# Altium schematic sheet main.SchDoc
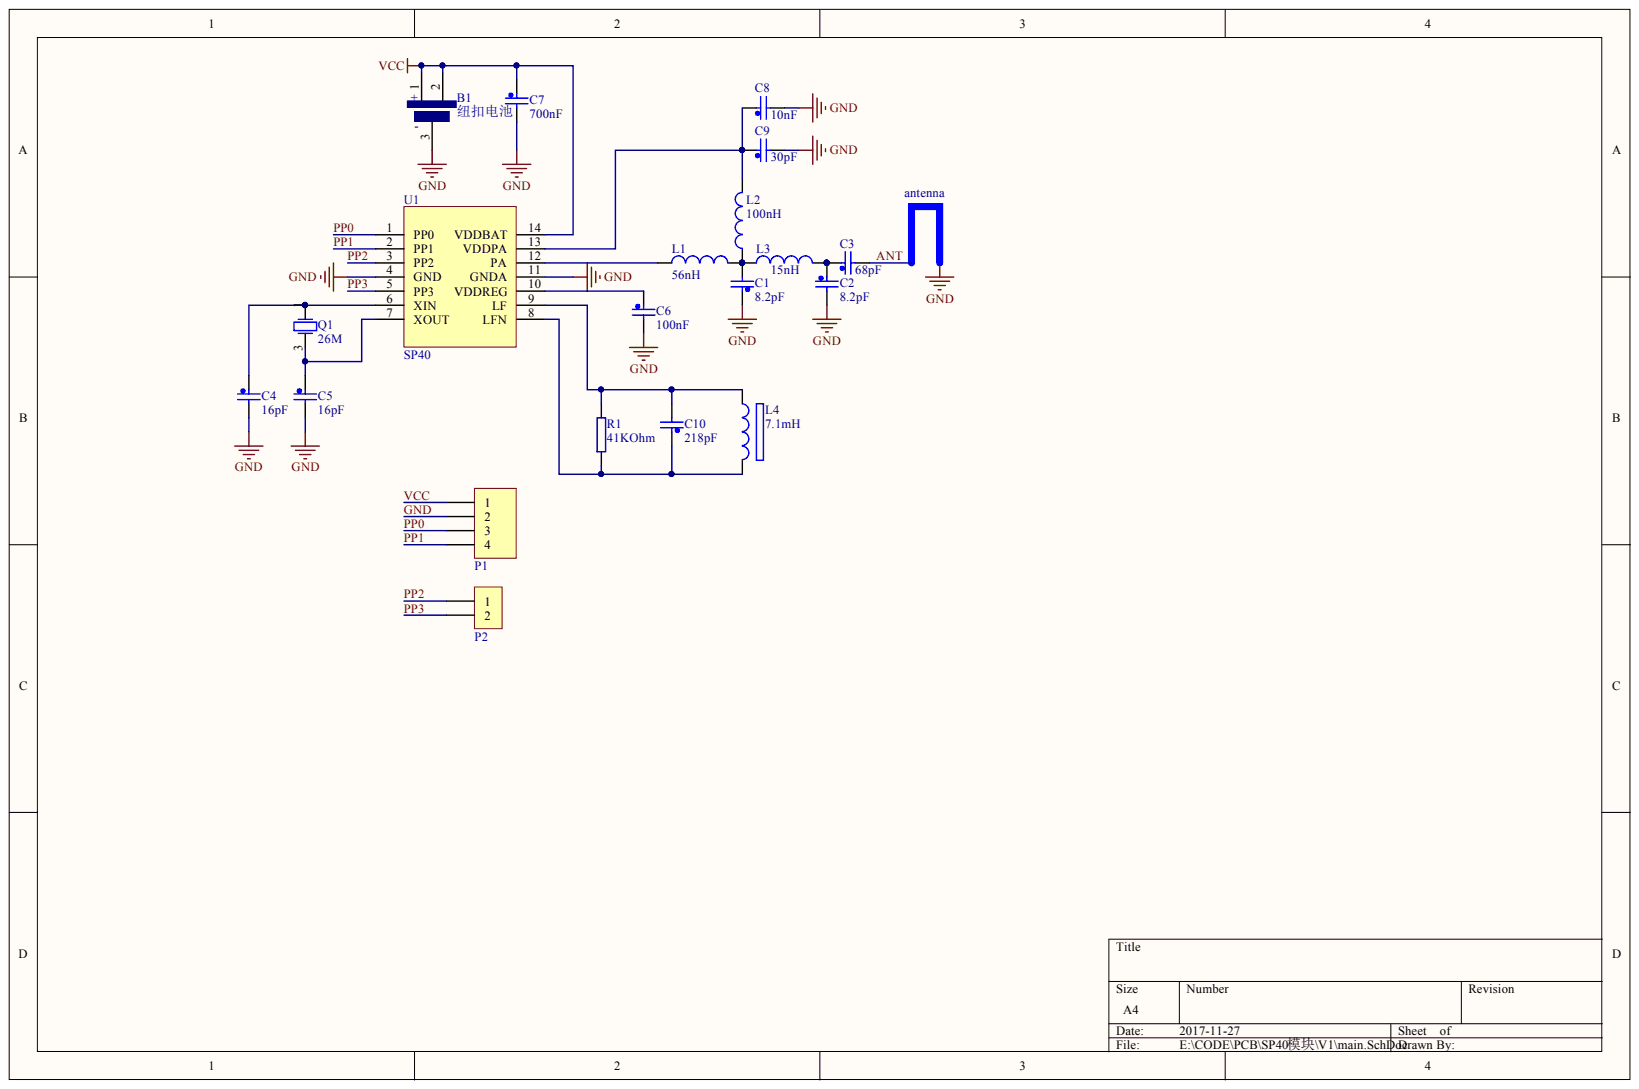
[diagram p0: main.SchDoc]

## Parts
B1  纽扣电池  [CR1632]
C1  8.2pF  [0603_C]
C2  8.2pF  [0603_C]
C3  68pF  [0603_C]
C4  16pF  [0603_C]
C5  16pF  [0603_C]
C6  100nF  [0603_C]
C7  700nF  [0603_C]
C8  10nF  [0603_C]
C9  30pF  [0603_C]
C10  218pF  [0603_C]
L1  56nH  [0603_L]
L2  100nH  [0603_L]
L3  15nH  [0603_L]
L4  7.1mH  [EPCOS_7.2mH]
P1  Header 4  [HDR_2.0_4]  (value not drawn)
P2  Header 2  [HDR_2.0_2]  (value not drawn)
Q1  26M  [XTAL_3225]
R1  41KOhm  [0603_R]
U1  SP40  [SP40]

## Nets
ANT: C3.2
GND: B1.3 C1.1 C2.2 C4.2 C5.2 C6.2 C7.2 C8.2 C9.2 P1.2 Q1.2 Q1.4 U1.4(GND) U1.11(GNDA)
PP0: P1.3 U1.1(PP0)
PP1: P1.4 U1.2(PP1)
PP2: P2.1 U1.3(PP2)
PP3: P2.2 U1.5(PP3)
VCC: B1.1 B1.2 C7.1 P1.1 U1.14(VDDBAT)
NetC1_2: C1.2 L1.2 L2.1 L3.1
NetC2_1: C2.1 C3.1 L3.2
NetC4_1: C4.1 Q1.1 U1.6(XIN)
NetC5_1: C5.1 Q1.3 U1.7(XOUT)
NetC6_1: C6.1 U1.10(VDDREG)
NetC8_1: C8.1 C9.1 L2.2 U1.13(VDDPA)
NetC10_1: C10.1 L4.2 R1.1 U1.8(LFN)
NetC10_2: C10.2 L4.1 R1.2 U1.9(LF)
NetL1_1: L1.1 U1.12(PA)

## Library data of the parts
C1-C10 = CAP: 贴片电容; Component Kind: Standard; Library Name: Library.IntLib
  C1 C2: Value: 8.2pF
  C3: Value: 68pF
  C4 C5: Value: 16pF
  C6: Value: 100nF
  C7: Value: 700nF
  C8: Value: 10nF
  C9: Value: 30pF
  C10: Value: 218pF
L1-L3 = Inductor: 贴片电感; Component Kind: Standard; Library Name: Library.IntLib
  L1: Value: 56nH
  L2: Value: 100nH
  L3: Value: 15nH
L4 = 低频电感: Component Kind: Standard; Description: 低频电感; Library Name: Schlib.SchLib; Value: 7.1mH
P1 = Header 4: Header, 4-Pin; Component Kind: Standard; Library Name: Library.IntLib
P2 = Header 2: Header, 2-Pin; Component Kind: Standard; Library Name: Library.IntLib
Q1 = XTAL: 晶振; Component Kind: Standard; Library Name: Schlib.SchLib; Value: 26M
R1 = RES: 贴片电阻; Component Kind: Standard; Library Name: Schlib.SchLib; Value: 41KOhm
U1 = SP40: SP40 胎压传感器; Component Kind: Standard; Library Name: Library.IntLib
B1 = 纽扣电池: Component Kind: Standard; Description: 纽扣电池; Library Name: Schlib.SchLib
